# Altium schematic sheet OpenTag3_Sensors.SchDoc(OpenTag3_Sensors)
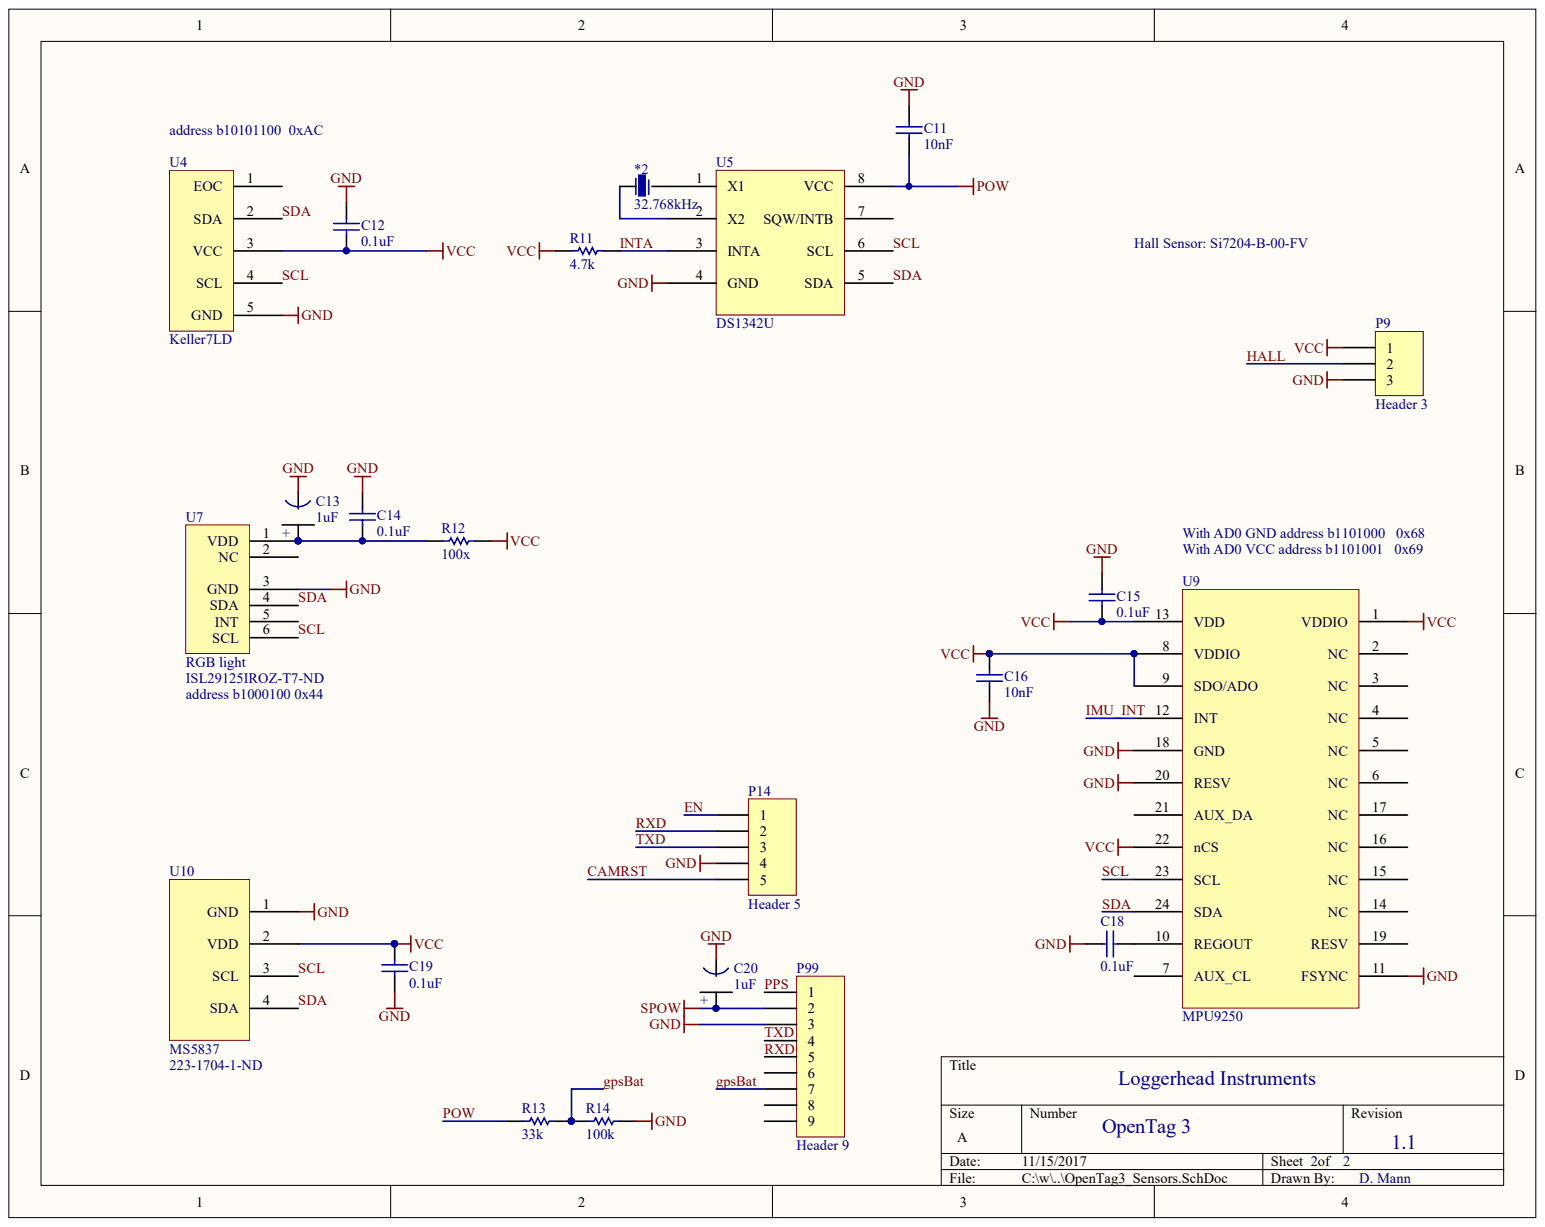
[diagram p0: OpenTag3_Sensors.SchDoc]

## Parts
*2  32.768kHz
C11  10nF
C12  0.1uF
C13  1uF
C14  0.1uF
C15  0.1uF
C16  10nF
C18  0.1uF
C19  0.1uF
C20  1uF
P9  Header 3
P14  Header 5
P99  Header 9
R11  4.7k
R12  100x
R13  33k
R14  100k
U4  Keller7LD
U5  DS1342U
U7  RGB light  Supplier Part Number 1: ISL29125IROZ-T7-ND
U9  MPU9250
U10  MS5837  Supplier Part Number 1: 223-1704-1-ND

## Nets
GND: C11.1 C12.2 C13.1 C14.2 C15.2 C16.2 C18.1 C19.1 C20.1 P9.3 P14.4 P99.3 R14.1 U4.5(GND) U5.4(GND) U7.3(GND) U9.11(FSYNC) U9.18(GND) U9.20(RESV) U10.1(GND)
SPOW: C20.2 P99.2
VCC: C12.1 C15.1 C16.1 C19.2 P9.1 R11.1 R12.1 U4.3(VCC) U9.1(VDDIO) U9.8(VDDIO) U9.9(SDO/ADO) U9.13(VDD) U9.22(nCS) U10.2(VDD)
CAMRST: P14.5
EN: P14.1
GPSBAT: P99.7 R13.1 R14.2
HALL: P9.2
IMU_INT: U9.12(INT)
INTA: R11.2 U5.3(INTA)
POW: C11.2 R13.2 U5.8(VCC)
PPS: P99.1
RXD: P14.2 P99.5
SCL: U4.4(SCL) U5.6(SCL) U7.6(SCL) U9.23(SCL) U10.3(SCL)
SDA: U4.2(SDA) U5.5(SDA) U7.4(SDA) U9.24(SDA) U10.4(SDA)
TXD: P14.3 P99.4
Net*2_1: *2.1 U5.2(X2)
Net*2_2: *2.2 U5.1(X1)
NetC13_2: C13.2 C14.1 R12.2 U7.1(VDD)
NetC18_2: C18.2 U9.10(REGOUT)

Not connected: P99 6 8 9; U4 1(EOC); U5 7(SQW/INTB); U7 2(NC) 5(INT); U9 2(NC) 3(NC) 4(NC) 5(NC) 6(NC) 7(AUX_CL) 14(NC) 15(NC) 16(NC) 17(NC) 19(RESV) 21(AUX_DA)

## Library data of the parts
C11 C12 C14-C16 C18 C19 = (no symbol name): Note: Footprint corresponds to dimensions for bulk cassette packaging. This case size has tightened tolerances.; Published: 17-Jul-2002; LatestRevisionDate: 19-Mar-2004; LatestRevisionNote: Silkscreen size reduced.; DatasheetDocument: Mar-2001; PackageDocument: Mar-2001; PackageReference: 0402; Code_JEITA: 0402; Code_IPC: 1005 [0402]; ComponentLink1Description: Manufacturer Link; ComponentLink1URL: http://www.kemet.com/; ComponentLink2Description: Datasheet; ComponentLink2URL: http://www.kemet.com/kemet/web/homepage/kechome.nsf/vapubfilesname/F3102TA.pdf/$file/F3102TA.pdf; Publisher: Altium Limited
  C11 C16: Value: 10nF
  C12 C14 C15 C18 C19: Value: 0.1uF
*2 C13 C20 P9 P14 P99 R11-R14 U4 U5 U7 U9 U10 = (no symbol name)
  C13 C20: Value: 1uF
  P9 P14 P99: LatestRevisionDate: 17-Jul-2002; LatestRevisionNote: Re-released for DXP Platform.
  R11-R14: Published: 17-Dec-2003; LatestRevisionDate: 19-Mar-2004; LatestRevisionNote: Silkscreen size reduced.; PackageDocument: Mar-2003; PackageReference: 3-0603; Code_IPC: 1608 [0603]; ComponentLink1Description: Manufacturer Link; ComponentLink1URL: http://www.panasonic.com/industrial/components/resistive_products.htm; ComponentLink2Description: Datasheet; ComponentLink2URL: http://www.panasonic.com/industrial/components/pdf/AOA0000CE2.pdf
  U4: PDF: http://www.kelleramerica.com/pdf-library/Piezoresistive%20OEM%20Pressure%20Transmitters%20with%20I2C%20interface%20and%20embedded%20signal%20conditioning.pdf
  U7 U10: Supplier 1: Digi-Key
  P9 P14 P99 R11-R14: Publisher: Altium Limited
